# Altium schematic sheet MCU.SchDoc
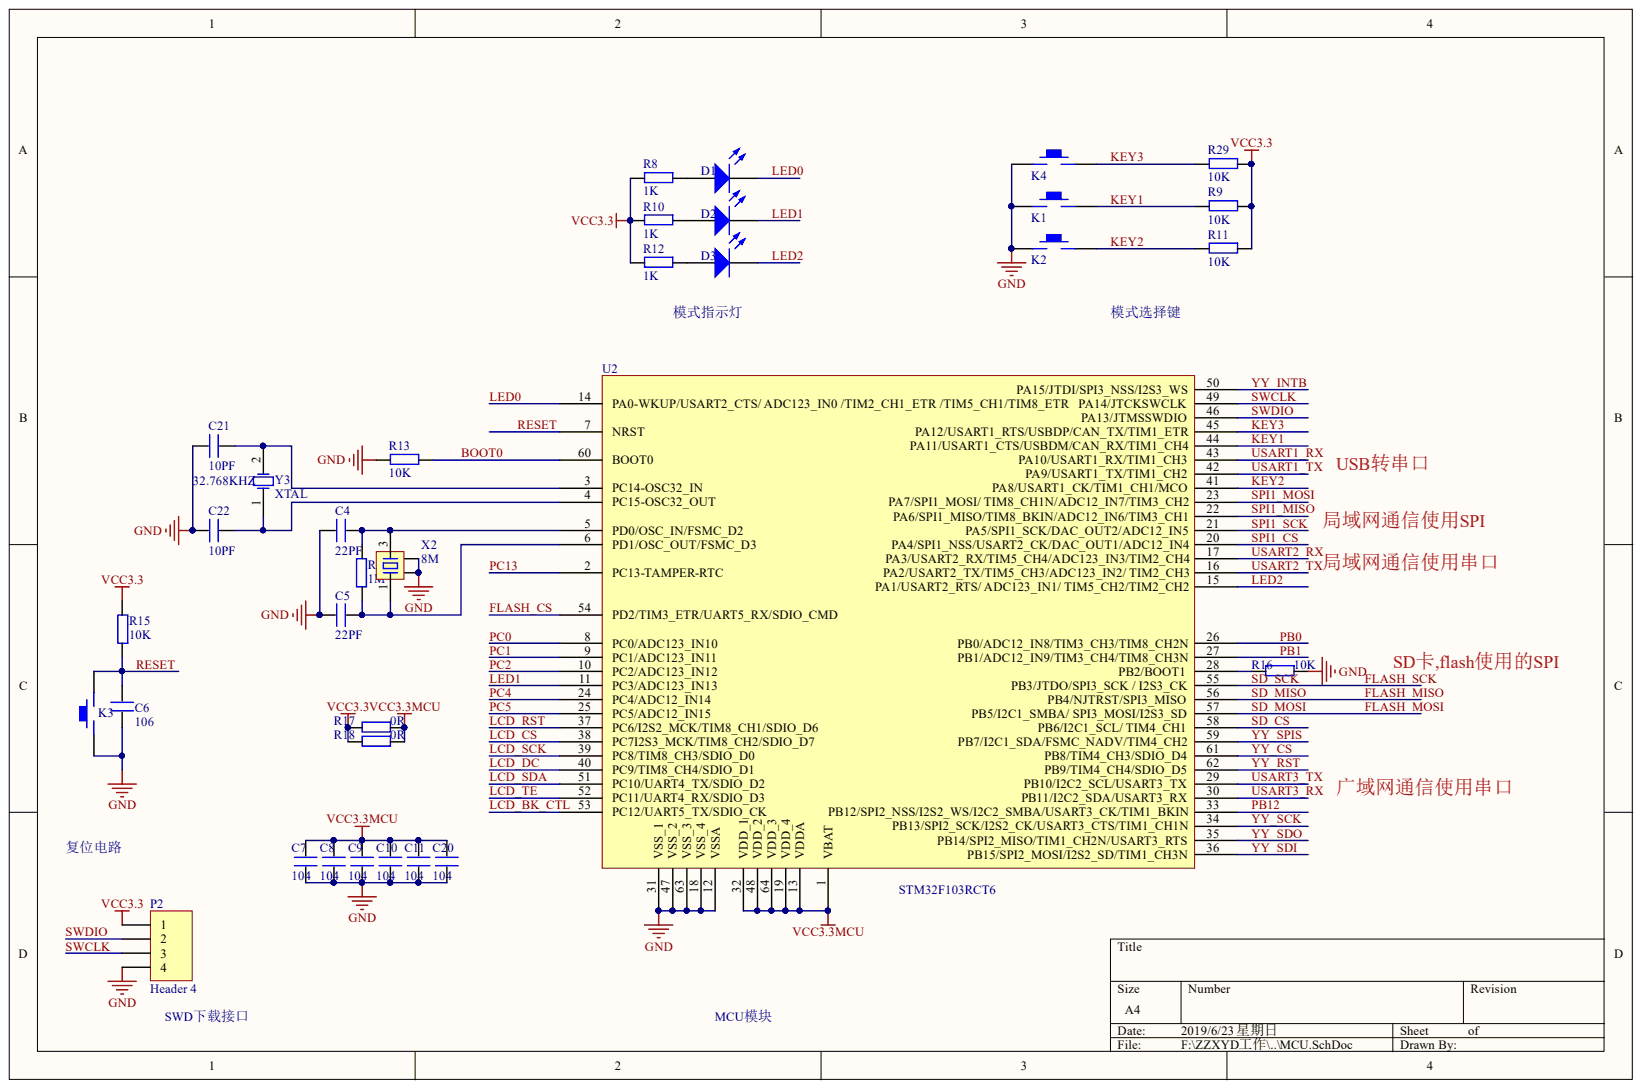
[diagram p0: MCU.SchDoc]

## Parts
C4  value 22PF  [0805C]  (hidden comment: CAP)
C5  value 22PF  [0805C]  (hidden comment: CAP)
C6  value 106  [0805C]  (hidden comment: CAP)
C7  value 104  [0805C]  (hidden comment: CAP)
C8  value 104  [0805C]  (hidden comment: CAP)
C9  value 104  [0805C]  (hidden comment: CAP)
C10  value 104  [0805C]  (hidden comment: CAP)
C11  value 104  [0805C]  (hidden comment: CAP)
C20  value 104  [0805C]  (hidden comment: CAP)
C21  value 10PF  [0805C]  (hidden comment: CAP)
C22  value 10PF  [0805C]  (hidden comment: CAP)
D1  LED0  [LED_0805]
D2  LED0  [LED_0805]
D3  LED0  [LED_0805]  (value not drawn)
K1  KEY_M  [KEY]  (value not drawn)
K2  KEY_M  [KEY]  (value not drawn)
K3  KEY_M  [KEY]  (value not drawn)
K4  KEY_M  [KEY]  (value not drawn)
P2  Header 4  [HDR1X4]
R8  value 1K  [0805]  (hidden comment: RES)
R9  value 10K  [0805]  (hidden comment: RES)
R10  value 1K  [0805]  (hidden comment: RES)
R11  value 10K  [0805]  (hidden comment: RES)
R12  value 1K  [0805]  (hidden comment: RES)
R13  value 10K  [0805]  (hidden comment: RES)
R14  value 1M  [0805]  (hidden comment: RES)
R15  RES  value 10K  [0805]
R16  value 10K  [0805]  (hidden comment: RES)
R17  value 0R  [0805]  (hidden comment: RES)
R18  value 0R  [0805]  (hidden comment: RES)
R29  value 10K  [0805]  (hidden comment: RES)
U2  STM32F103RCT6  [LQFP64S]
X2  8M  [3225_OSC]
Y3  XTAL  value 32.768KHZ  [XTAL]

## Nets
GND: C4.1 C5.1 C6.2 C7.2 C8.2 C9.2 C10.2 C11.2 C20.2 C21.1 C22.1 K1.1 K2.1 K3.1 K4.1 P2.4 R13.2 R16.1 U2.12(VSSA) U2.18(VSS_4) U2.31(VSS_1) U2.47(VSS_2) U2.63(VSS_3) X2.2 X2.4
VCC3.3: P2.1 R8.2 R9.1 R10.2 R11.1 R12.2 R15.2 R17.2 R18.2 R29.1
VCC3.3MCU: C7.1 C8.1 C9.1 C10.1 C11.1 C20.1 R17.1 R18.1 U2.1(VBAT) U2.13(VDDA) U2.19(VDD_4) U2.32(VDD_1) U2.48(VDD_2) U2.64(VDD_3)
BOOT0: R13.1 U2.60(BOOT0)
FLASH_CS: U2.54(PD2/TIM3_ETR/UART5_RX/SDIO_CMD)
FLASH_MISO: U2.56(PB4/NJTRST/SPI3_MISO)
FLASH_MOSI: U2.57(PB5/I2C1_SMBA/_SPI3_MOSI/I2S3_SD)
FLASH_SCK: U2.55(PB3/JTDO/SPI3_SCK_/_I2S3_CK)
KEY1: K1.2 R9.2 U2.44(PA11/USART1_CTS/USBDM/CAN_RX/TIM1_CH4)
KEY2: K2.2 R11.2 U2.41(PA8/USART1_CK/TIM1_CH1/MCO)
KEY3: K4.2 R29.2 U2.45(PA12/USART1_RTS/USBDP/CAN_TX/TIM1_ETR)
LCD_BK_CTL: U2.53(PC12/UART5_TX/SDIO_CK)
LCD_CS: U2.38(PC7I2S3_MCK/TIM8_CH2/SDIO_D7)
LCD_DC: U2.40(PC9/TIM8_CH4/SDIO_D1)
LCD_RST: U2.37(PC6/I2S2_MCK/TIM8_CH1/SDIO_D6)
LCD_SCK: U2.39(PC8/TIM8_CH3/SDIO_D0)
LCD_SDA: U2.51(PC10/UART4_TX/SDIO_D2)
LCD_TE: U2.52(PC11/UART4_RX/SDIO_D3)
LED0: D1.2 U2.14
LED1: D2.2 U2.11(PC3/ADC123_IN13)
LED2: D3.2 U2.15(PA1/USART2_RTS/_ADC123_IN1/_TIM5_CH2/TIM2_CH2)
PB0: U2.26(PB0/ADC12_IN8/TIM3_CH3/TIM8_CH2N)
PB1: U2.27(PB1/ADC12_IN9/TIM3_CH4/TIM8_CH3N)
PB12: U2.33(PB12/SPI2_NSS/I2S2_WS/I2C2_SMBA/USART3_CK/TIM1_BKIN)
PC0: U2.8(PC0/ADC123_IN10)
PC1: U2.9(PC1/ADC123_IN11)
PC2: U2.10(PC2/ADC123_IN12)
PC4: U2.24(PC4/ADC12_IN14)
PC5: U2.25(PC5/ADC12_IN15)
PC13: U2.2(PC13-TAMPER-RTC)
RESET: C6.1 K3.2 R15.1 U2.7(NRST)
SD_CS: U2.58(PB6/I2C1_SCL/_TIM4_CH1)
SPI1_CS: U2.20(PA4/SPI1_NSS/USART2_CK/DAC_OUT1/ADC12_IN4)
SPI1_MISO: U2.22(PA6/SPI1_MISO/TIM8_BKIN/ADC12_IN6/TIM3_CH1)
SPI1_MOSI: U2.23(PA7/SPI1_MOSI/_TIM8_CH1N/ADC12_IN7/TIM3_CH2)
SPI1_SCK: U2.21(PA5/SPI1_SCK/DAC_OUT2/ADC12_IN5)
SWCLK: P2.3 U2.49(PA14/JTCKSWCLK)
SWDIO: P2.2 U2.46(PA13/JTMSSWDIO)
USART1_RX: U2.43(PA10/USART1_RX/TIM1_CH3)
USART1_TX: U2.42(PA9/USART1_TX/TIM1_CH2)
USART2_RX: U2.17(PA3/USART2_RX/TIM5_CH4/ADC123_IN3/TIM2_CH4)
USART2_TX: U2.16(PA2/USART2_TX/TIM5_CH3/ADC123_IN2/_TIM2_CH3)
USART3_RX: U2.30(PB11/I2C2_SDA/USART3_RX)
USART3_TX: U2.29(PB10/I2C2_SCL/USART3_TX)
YY_CS: U2.61(PB8/TIM4_CH3/SDIO_D4)
YY_INTB: U2.50(PA15/JTDI/SPI3_NSS/I2S3_WS)
YY_RST: U2.62(PB9/TIM4_CH4/SDIO_D5)
YY_SCK: U2.34(PB13/SPI2_SCK/I2S2_CK/USART3_CTS/TIM1_CH1N)
YY_SDI: U2.36(PB15/SPI2_MOSI/I2S2_SD/TIM1_CH3N)
YY_SDO: U2.35(PB14/SPI2_MISO/TIM1_CH2N/USART3_RTS)
YY_SPIS: U2.59(PB7/I2C1_SDA/FSMC_NADV/TIM4_CH2)
NetC4_2: C4.2 R14.1 U2.5(PD0/OSC_IN/FSMC_D2) X2.3
NetC5_2: C5.2 R14.2 U2.6(PD1/OSC_OUT/FSMC_D3) X2.1
NetC21_2: C21.2 U2.3(PC14-OSC32_IN) Y3.2
NetC22_2: C22.2 U2.4(PC15-OSC32_OUT) Y3.1
NetD1_1: D1.1 R8.1
NetD2_1: D2.1 R10.1
NetD3_1: D3.1 R12.1
NetR16_2: R16.2 U2.28(PB2/BOOT1)

## Library data of the parts
D1-D3 = LED: 发光二极管; Component Kind: Standard; LatestRevisionDate: 17-Jul-2002; LatestRevisionNote: Re-released for DXP Platform.; PackageDocument: Feb-2002; PackageReference: 3.2X1.6X1.1; Published: 8-Jun-2000; Simulation: LED2; Library Name: 主控端最小系统.SCHLIB; Publisher: Altium Limited
P2 = Header 4: Header, 4-Pin; Component Kind: Standard; LatestRevisionDate: 17-Jul-2002; LatestRevisionNote: Re-released for DXP Platform.; Library Name: Miscellaneous Connectors.IntLib; Signal Integrity: Connector; Publisher: Altium Limited
X2 = XTAL-4P: 4脚无源晶振; 版权归属: 源创客; BOM名称: 4脚无源晶振; Component Kind: Standard; Library Name: 10.1 - 保险丝-晶振.SchLib; 学习资源: www.ooocke.com
Y3 = XTAL: 无源晶振; Component Kind: Standard; LatestRevisionDate: 17-Jul-2002; LatestRevisionNote: Re-released for DXP Platform.; Published: 8-Jun-2000; Simulation: XTAL; Library Name: 主控端最小系统.SCHLIB; Publisher: Altium Limited
C4-C11 C20-C22 = CAP: Component Kind: Standard; Description: CAP; Library Name: 主控端最小系统.SCHLIB
K1-K4 = KEY_M: Component Kind: Standard; Library Name: 主控端最小系统.SCHLIB
R8-R18 R29 = RES: Component Kind: Standard; Description: RES; Library Name: 主控端最小系统.SCHLIB
U2 = STM32F103RCT6: Component Kind: Standard; Library Name: 主控端最小系统.SCHLIB
